# Altium schematic sheet 4_Power.SchDoc
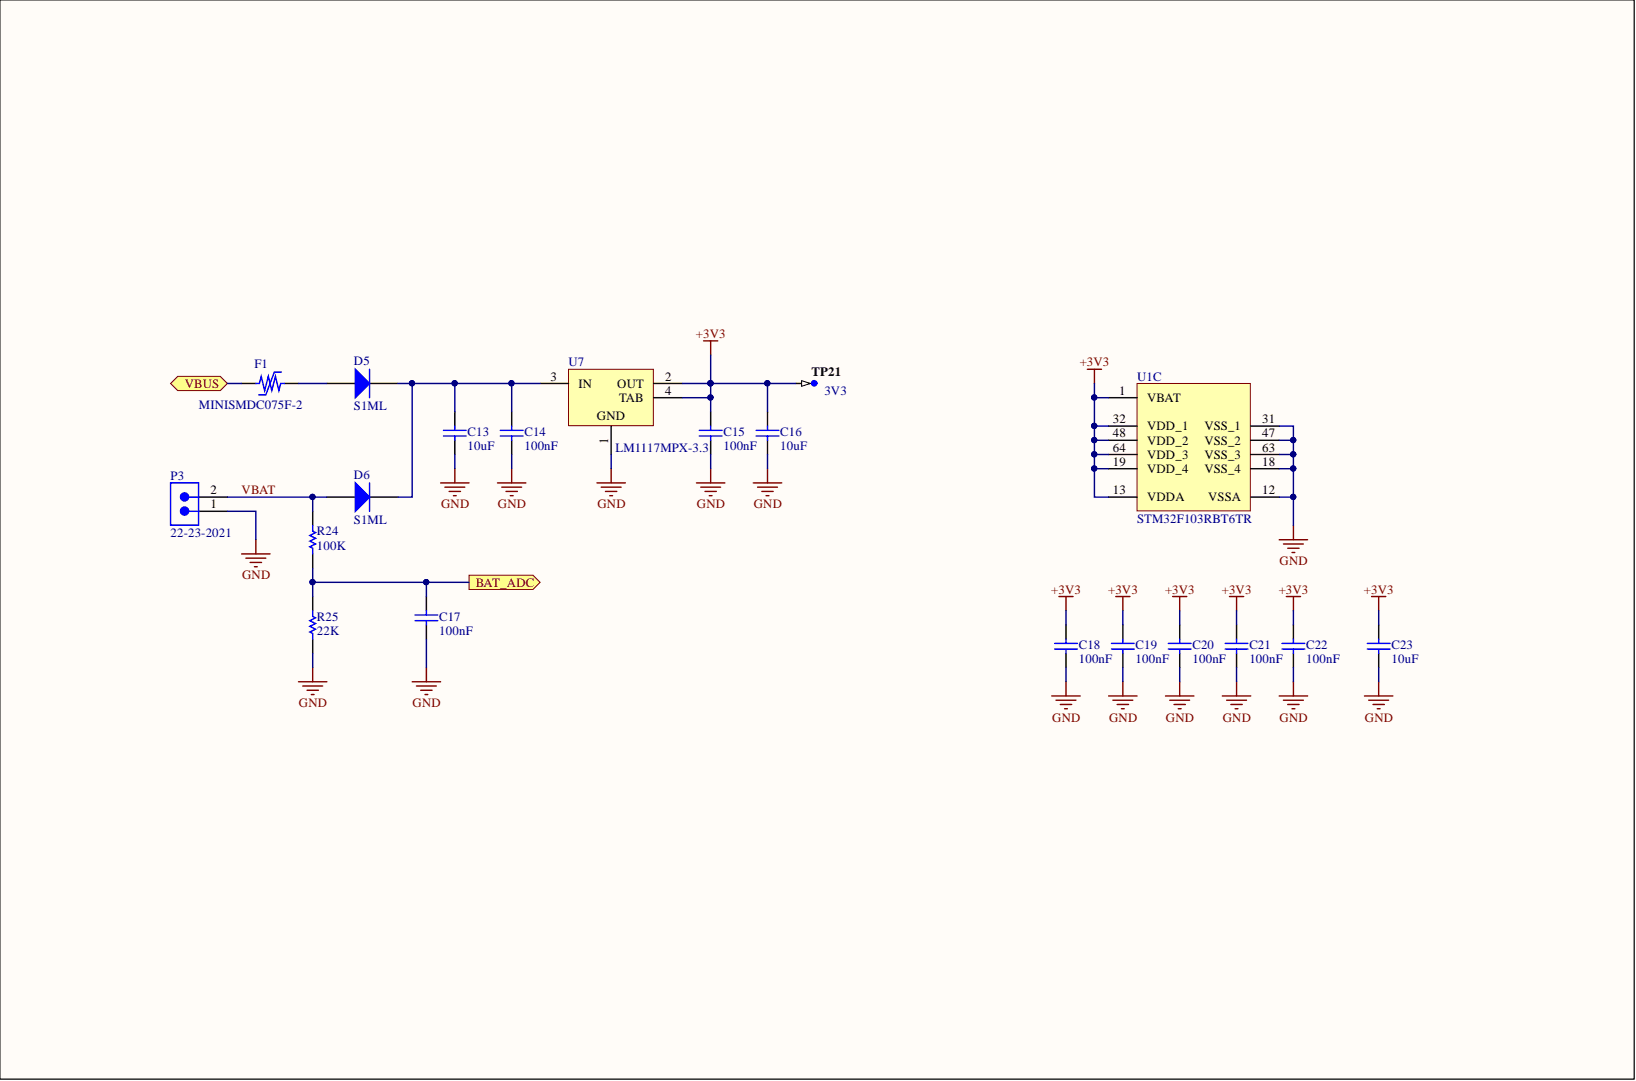
[diagram p0: 4_Power.SchDoc]

## Parts
C13  10uF  [CAPC1206(3216)130_L]
C14  100nF  [CAPC0603(1608)100_M]
C15  100nF  [CAPC0603(1608)100_M]
C16  10uF  [CAPC1206(3216)130_L]
C17  100nF  [CAPC0603(1608)100_M]
C18  100nF  [CAPC0603(1608)100_M]
C19  100nF  [CAPC0603(1608)100_M]
C20  100nF  [CAPC0603(1608)100_M]
C21  100nF  [CAPC0603(1608)100_M]
C22  100nF  [CAPC0603(1608)100_M]
C23  10uF  [CAPC1206(3216)130_L]
D5  S1ML  [TWS-S1ML_V]
D6  S1ML  [TWS-S1ML_V]
F1  MINISMDC075F-2  [TECO-MINISMDC075F_V]
P3  22-23-2021  [MOLX-22-23-2021_V]
R24  100K  [RESC0603(1608)_M]
R25  22K  [RESC0603(1608)_M]
TP21  3V3  [TP-SM-C-100]  (no BOM)
U1  STM32F103RBT6TR  [STM-LQFP64_N]  (part C)
U7  LM1117MPX-3.3  [MP04A_N]

## Ports
BAT_ADC, VBUS

## Nets
+3V3: C15.2 C16.2 C18.2 C19.2 C20.2 C21.2 C22.2 C23.2 TP21.1 U1.1(VBAT) U1.13(VDDA) U1.19(VDD_4) U1.32(VDD_1) U1.48(VDD_2) U1.64(VDD_3) U7.2(OUT) U7.4(TAB)
GND: C13.1 C14.1 C15.1 C16.1 C17.1 C18.1 C19.1 C20.1 C21.1 C22.1 C23.1 P3.1 R25.1 U1.12(VSSA) U1.18(VSS_4) U1.31(VSS_1) U1.47(VSS_2) U1.63(VSS_3) U7.1
BAT_ADC: C17.2 R24.1 R25.2 ; port BAT_ADC
VBAT: D6.1 P3.2 R24.2
VBUS: F1.1 ; port VBUS
NetC13_2: C13.2 C14.2 D5.2 D6.2 U7.3(IN)
NetD5_1: D5.1 F1.2

## Library data of the parts
C13 C16 C23 = CMP-1037-04977-1: CAP 10uF 16V -20% to +80% 1206 (3216 Metric) Thickness 1.3mm SMD; Case-EIA: 1206; Case-Metric: 3216; Component Kind: Standard; Library Name: Altium Content Vault; Max Thickness: 1.3 mm; Rated Voltage: 16 V; Technology: SMT; Tolerance: -20% to +80%; Value: 10uF
C14 C15 C17-C22 = CMP-1035-02589-1: CAP 100nF 25V ±20% 0603 (1608 Metric) Thickness 1mm SMD; Case-EIA: 0603; Case-Metric: 1608; Tolerance: Â±20%; Component Kind: Standard; Library Name: Altium Content Vault; Max Thickness: 1 mm; Rated Voltage: 25 V; Technology: SMT; Value: 100nF
D5 D6 = CMP-2000-07014-1: Rectifier, 1 A, 1.1 V, -55 to 175 degC, 2-Pin SMD, RoHS, Tape and Reel; Manufacturer: Taiwan Semiconductor; Diode Configuration: Single; Forward Current If(AV): 1A; Forward Surge Current Ifsm Max: 30A; Forward Voltage VF Max: 1.1V; No. of Pins: 2Pin; Repetitive Reverse Voltage Vrrm Max: 1kV; Reverse Recovery Time trr Max: 1.8µs; SVHC: NoSVHC(15-Jun-2015); Component Kind: Standard; Datasheet URL: http://www.taiwansemi.com/products/datasheet/S1AL%20SERIES_O15.pdf; Datasheet Version: Rev. O15, 05/2015; Diode Case Style: SMD; Library Name: Altium Content Vault; Manufacturer URL: http://www.taiwansemi.com/; Mounting Technology: SurfaceMount; Operating Temperature Max: 150°C; Package Description: 2-Pin Surface Mount Device, Body 2.8 x 1.8 mm; Package Reference: S1ML; Packaging: CutTape; Product Range: -
F1 = CMP-2000-06707-1: PolySwitch Resettable Device, 13.2 V, 0.75 A, -40 to 85 degC, 2-Pin SMD, RoHS, Tape and Reel; Manufacturer: TE Connectivity; Holding Current: 750mA; Initial Resistance Max: 0.45    ohm; Operating Voltage: 13.2    V; Product Range: PolySwitchminiSMDCSeries; SVHC: NoSVHC(15-Jun-2015); Tripping Current: 1.5A; Voltage Rating: 13.2VDC; Component Kind: Standard; Datasheet URL: http://www.te.com/commerce/DocumentDelivery/DDEController?Action=srchrtrv&DocNm=CLP00010&DocType=CS&DocLang=English; Datasheet Version: 11/2013; Library Name: Altium Content Vault; Manufacturer URL: http://www.te.com/; Mounting Technology: SurfaceMount; Operating Temperature Max: 85°C; Operating Temperature Min: -40°C; Package Description: 2-Pin Surface Mount Device, Body 4.55 x 3.24 mm; Package Reference: MINISMDC075F; PTC Fuse Case Style: SMD; Thermistor Mounting: SMD
P3 = CMP-1704-00001-1: Male Header, Pitch 2.54 mm, 1 x 2 Position, Height 10.67 mm, Tail Length 3.56 mm, 0 to 75 degC, RoHS, Bulk; Manufacturer: Molex; Component Kind: Standard; ComponentLink1Description: Manufacturer URL; ComponentLink1URL: http://www.molex.com/; ComponentLink2Description: Datasheet; ComponentLink2URL: https://datasheet.ciiva.com/19454/232266-19454304.pdf; Library Name: Altium Content Vault; Mounting Technology: Through Hole; PackageDescription: Male Header, Pitch 2.54 mm, 1 x 2 Position, Height 10.67 mm, Tail Length 3.56 mm; PackageReference: 22-23-2021; PartNumber: 22-23-2021
R24 = CMP-1012-00736-1: 100K 0.1W 1% 0603 (1608 Metric)  SMD; Case-EIA: 0603; Case-Metric: 1608; Value: 100000.00 Ohm; Component Kind: Standard; Library Name: Altium Content Vault; Power: 0.1 W; Technology: SMT; Tolerance: 1 %
R25 = CMP-1012-00659-1: 22K 0.1W 1% 0603 (1608 Metric)  SMD; Case-EIA: 0603; Case-Metric: 1608; Value: 22000.00 Ohm; Component Kind: Standard; Library Name: Altium Content Vault; Power: 0.1 W; Technology: SMT; Tolerance: 1 %
TP21 = CMP-0484-00002-2: Test Point, Surface Mount, Circular, Pad Diameter 1 mm; Manufacturer: No Vendor; Library Name: Altium Content Vault; PartNumber: TP-SM-C-100
U1 = CMP-0237-00169-2: ARM Cortex-M3 32-bit MCU, 128 KB Flash, 20 KB Internal RAM, 51 I/Os, 64-pin LQFP, -40 to 85 degC, Tape and Reel; A/D Converter: 2x12-bit (16 Channel); FLASH Size (Prog) (kB): 128; I/Os (High Current): 51; Internal RAM Size (kB): 20; Operating Frequency(F) (Processor speed) (MHz): 72; Supply Voltage(Vcc) min (V): 2; Component Kind: Standard; ComponentLink1Description: Manufacturer URL; ComponentLink1URL: http://www.st.com/web/en/home.html; ComponentLink2Description: Datasheet; ComponentLink2URL: http://www.st.com/st-web-ui/static/active/en/resource/technical/document/datasheet/CD00161566.pdf; ComponentLink3Description: Package Specification; ComponentLink3URL: http://www.st.com/st-web-ui/static/active/en/resource/technical/document/datasheet/DM00037051.pdf; Core: ARM Cortex-M3; DatasheetVersion: Rev. 16; Library Name: Altium Content Vault; Mounting Technology: Surface Mount; PackageDescription: 64-Pin Low Profile Quad Flat Package, 10 x 10 mm Body, 0.5 mm Pitch; PackageReference: LQFP64; PackageVersion: Rev. 4, 06/2013; Packing: Tape and Reel; PartId: 1; PartNumber: STM32F103RBT6TR
U7 = CMP-0062-01659-3: 800mA Low-Dropout Linear Regulator, 4-pin SOT-223; Manufacturer: National Semiconductor; CompType: LDO IC; High-Reliability: Y; PackageTopMarking: XYTT*N05A; PowerWise: N; Code_IPC: SOT223-4; Code_JEDEC: TO-261-AA; Component Kind: Standard; ComponentLink1Description: Manufacturer URL; ComponentLink1URL: http://www.national.com/; ComponentLink2Description: LM1117 Datasheet; ComponentLink2URL: http://www.national.com/ds/LM/LM1117.pdf; ComponentLink3Description: LM1117 Web Page; ComponentLink3URL: http://www.national.com/pf/LM/LM1117.html; ComponentLink4Description: MP04A Package Page; ComponentLink4URL: http://www.national.com/packaging/folders/mp04a.html; DatasheetVersion: Apr-2006; Library Name: Altium Content Vault; PackageDescription: Molded SOT-223, 6.50 x 3.56 x 1.6mm Body, 4 Lead, 2.3mm Pitch; PackageReference: MP04A; PackageVersion: revB, Apr-2003; PartNumber: LM1117MPX-3.3; PinCount: 4; RevisionNote: SCH symbol layout adjusted; RoHS: N
